# Altium schematic sheet PowerSupply.SchDoc(PowerSupply)
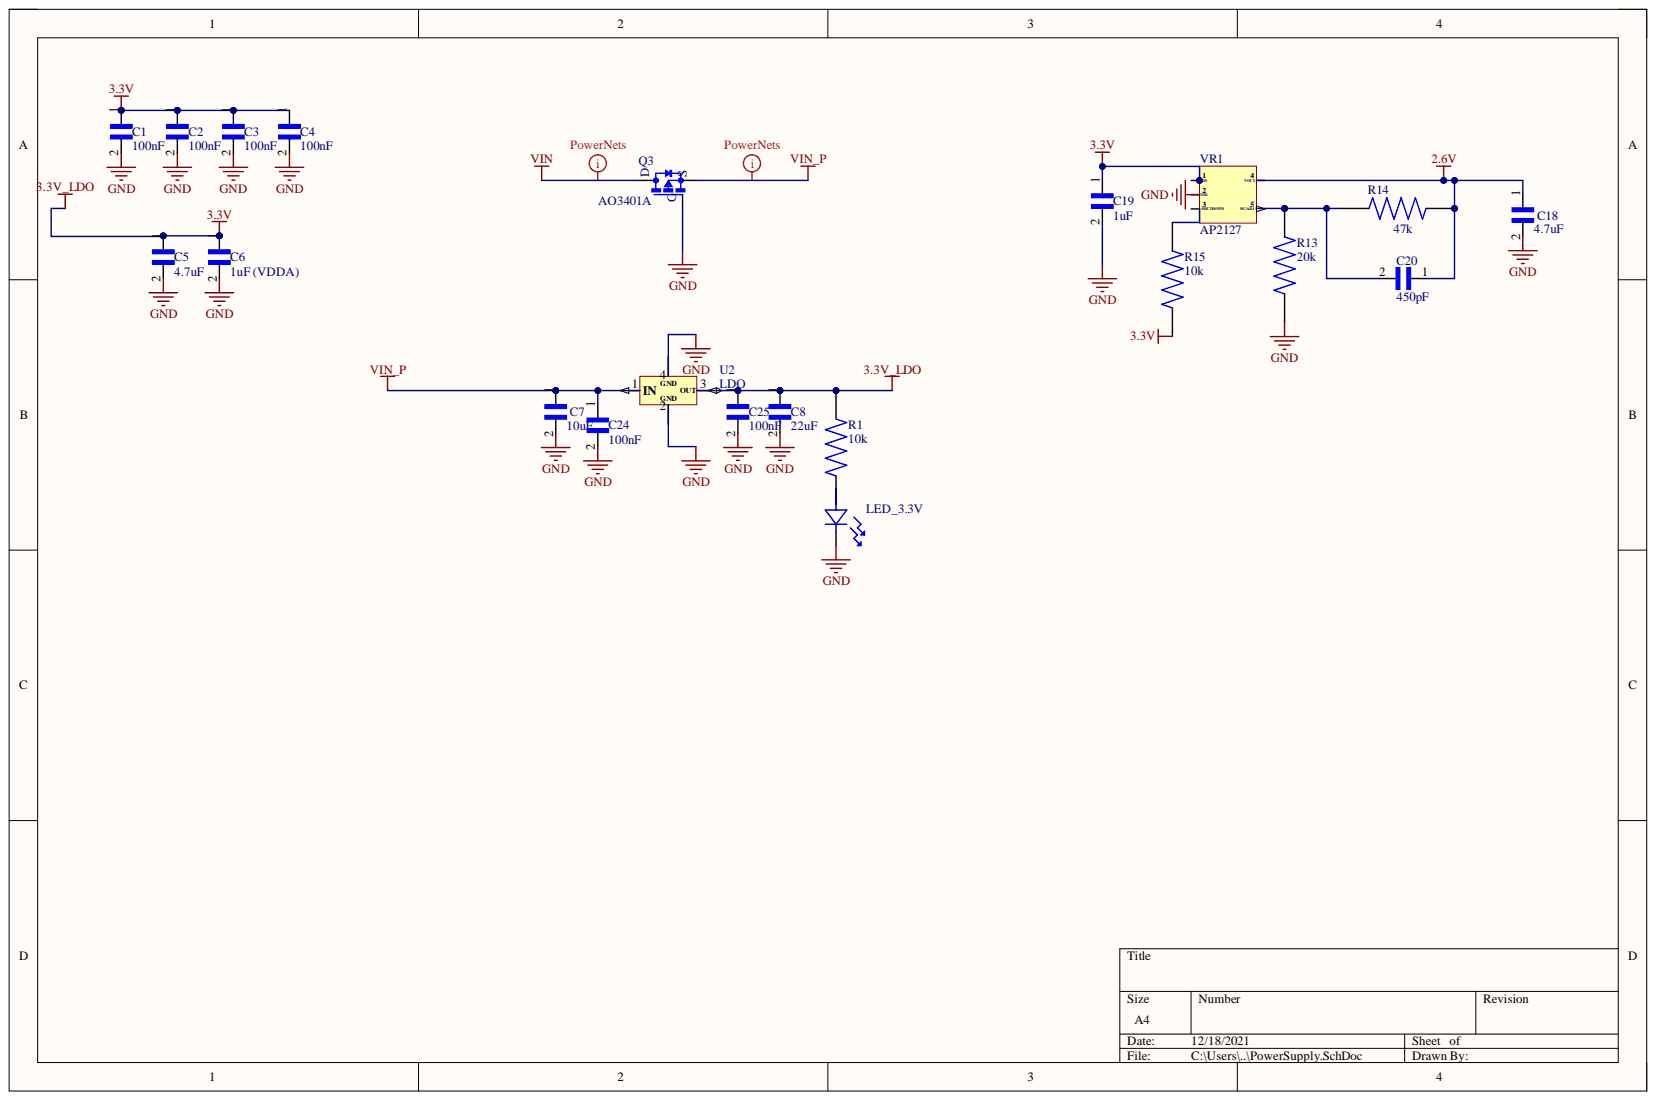
[diagram p0: PowerSupply.SchDoc]

## Parts
C1  100nF  [CAPC1005X55N]
C2  100nF  [CAPC1005X55N]
C3  100nF  [CAPC1005X55N]
C4  100nF  [CAPC1005X55N]
C5  4.7uF  [CAPC1608X90N]
C6  1uF (VDDA)  [CAPC1005X55N]
C7  10uF  [CAPC3216X180N]
C8  22uF  [CAPC3216X180N]
C18  4.7uF  [CAPC1608X90N]
C19  1uF  [CAPC1608X90N]
C20  450pF  [CAPC1005X55N]
C24  100nF  [CAPC1005X55N]
C25  100nF  [CAPC1005X55N]
LED_3.3V  CMD17-21VRC_TR8  [LED_CMD17-21VRC_TR8]  (value not drawn)
Q3  AO3401A  [SOT23]
R1  10k  [RESC1005X35N]
R13  20k  [RESC1005X35N]
R14  47k  [RESC1005X35N]
R15  10k  [RESC1005X35N]
U2  LDO  [AP7361C-3.3]
VR1  AP2127  [SOT95P270X145-5N(SOT23-5)]

## Nets
2.6V: C18.1 C20.1 R14.2 VR1.4(VOUT)
3.3V: C1.1 C2.1 C3.1 C4.1 C5.1 C6.1 C8.1 C19.1 C25.1 R1.1 R15.2 U2.3(OUT) VR1.1(VIN)
GND: C1.2 C2.2 C3.2 C4.2 C5.2 C6.2 C7.2 C8.2 C18.2 C19.2 C24.2 C25.2 LED_3.3V.2 Q3.1 R13.2 U2.2 U2.4 VR1.2
VIN: Q3.3
VIN_P: C7.1 C24.1 Q3.2 U2.1(IN)
NetC20_2: C20.2 R13.1 R14.1 VR1.5(NC/ADJ)
NetLED_3.3V_1: LED_3.3V.1 R1.2
NetR15_1: R15.1 VR1.3(/SHUTDOWN)

## Library data of the parts
C1-C4 C6 C20 C24 C25 = CL05B104KO5NNNC: 0.1ÂµF Â±10% 16V Ceramic Capacitor X7R 0402 _1005 Metric_; MF: Samsung Electro-Mechanics; Availability: Unavailable; Component Kind: Standard; Library Name: CL05B104KO5NNNC.IntLib; MP: CL05B104KO5NNNC; Package: 0402 Samsung; Price: None; SnapEDA_Link: https://www.snapeda.com/parts/CL05B104KO5NNNC/Samsung%20Electro-Mechanics/view-part/3598311/?ref=snap
C5 C18 C19 = CL10A475KO8NNNC: 4.7ÂµF Â±10% 16V Ceramic Capacitor X5R 0603 (1608 Metric); MF: Samsung Electro-Mechanics; Arrow_Electronics-Purchase-URL: https://snapeda.com/shop?store=Arrow+Electronics&id=3598183; Availability: Unavailable; Component Kind: Standard; Library Name: CL10A475KO8NNNC.IntLib; MP: CL10A475KO8NNNC; Package: 0603 Samsung; Price: None; SnapEDA_Link: https://www.snapeda.com/parts/CL10A475KO8NNNC/Samsung%20Electro-Mechanics/view-part/3598183/?ref=snap
C7 C8 = CL31A226KAHNNNE: 22ÂµF Â±10% 25V Ceramic Capacitor X5R 1206 _3216 Metric_; MF: Samsung Electro-Mechanics; Component Kind: Standard; Digi-Key_Part_Number: 1276-3047-1-ND; Digi-Key_Purchase_URL: https://www.digikey.com/product-detail/en/samsung-electro-mechanics/CL31A226KAHNNNE/1276-3047-1-ND/3891133?utm_source=snapeda&utm_medium=aggregator&utm_campaign=symbol; Library Name: CL31A226KAHNNNE.IntLib; MP: CL31A226KAHNNNE; Package: 1206 Samsung; SnapEDA_Link: https://www.snapeda.com/parts/CL31A226KAHNNNE/Samsung%20Electro-Mechanics/view-part/3598188/?ref=snap
LED_3.3V = CMD17-21VRC_TR8: KÄ±rmÄ±zÄ± LED GÃ¶stergesi - AyrÄ±k 2V 0805 (2012 Metrik); MF: Visual; MP: CMD17-21VRC/TR8; Availability: Unavailable; Component Kind: Standard; DigiKey-Purchase-URL: https://snapeda.com/shop?store=DigiKey&id=570104; Library Name: CMD17-21VRC_TR8.IntLib; Package: 0805 VCC; Price: None; SnapEDA_Link: https://www.snapeda.com/parts/CMD17-21VRC/TR8/Visual%20Communications%20Company%20-%20VCC/view-part/570104/?ref=snap
Q3 = AO3401A: P-Channel 30V 4A (Ta) 1.4W (Ta) Surface Mount SOT-23-3L; MF: Alpha &; Arrow_Electronics-Purchase-URL: https://snapeda.com/shop?store=Arrow+Electronics&id=227978; Component Kind: Standard; Digi-Key_Part_Number: 785-1001-1-ND; DigiKey-Purchase-URL: https://snapeda.com/shop?store=DigiKey&id=227978; Library Name: AO3401A.IntLib; MP: AO3401A; Package: SOT-23 Alpha &amp; Omega Semiconductor; SnapEDA_Link: https://www.snapeda.com/parts/AO3401A/Alpha%20&%20Omega%20Semiconductor%20Inc./view-part/227978/?ref=snap
R1 R13-R15 = RN73C1E1K24BTDF: RN 0402 1K24 0.1% 10PPM 1K RL; Availability: Unavailable; Component Kind: Standard; Library Name: RN73C1E1K24BTDF.IntLib; MP: RN73C1E1K24BTDF; Package: None; Price: None
U2 = AP7361C-3.3VV: Component Kind: Standard; Library Name: Schlib1.SchLib
VR1 = Component_1: Component Kind: Standard; Library Name: LDO_AP2127.IntLib
